# Altium schematic sheet Audio_Outputs.SchDoc
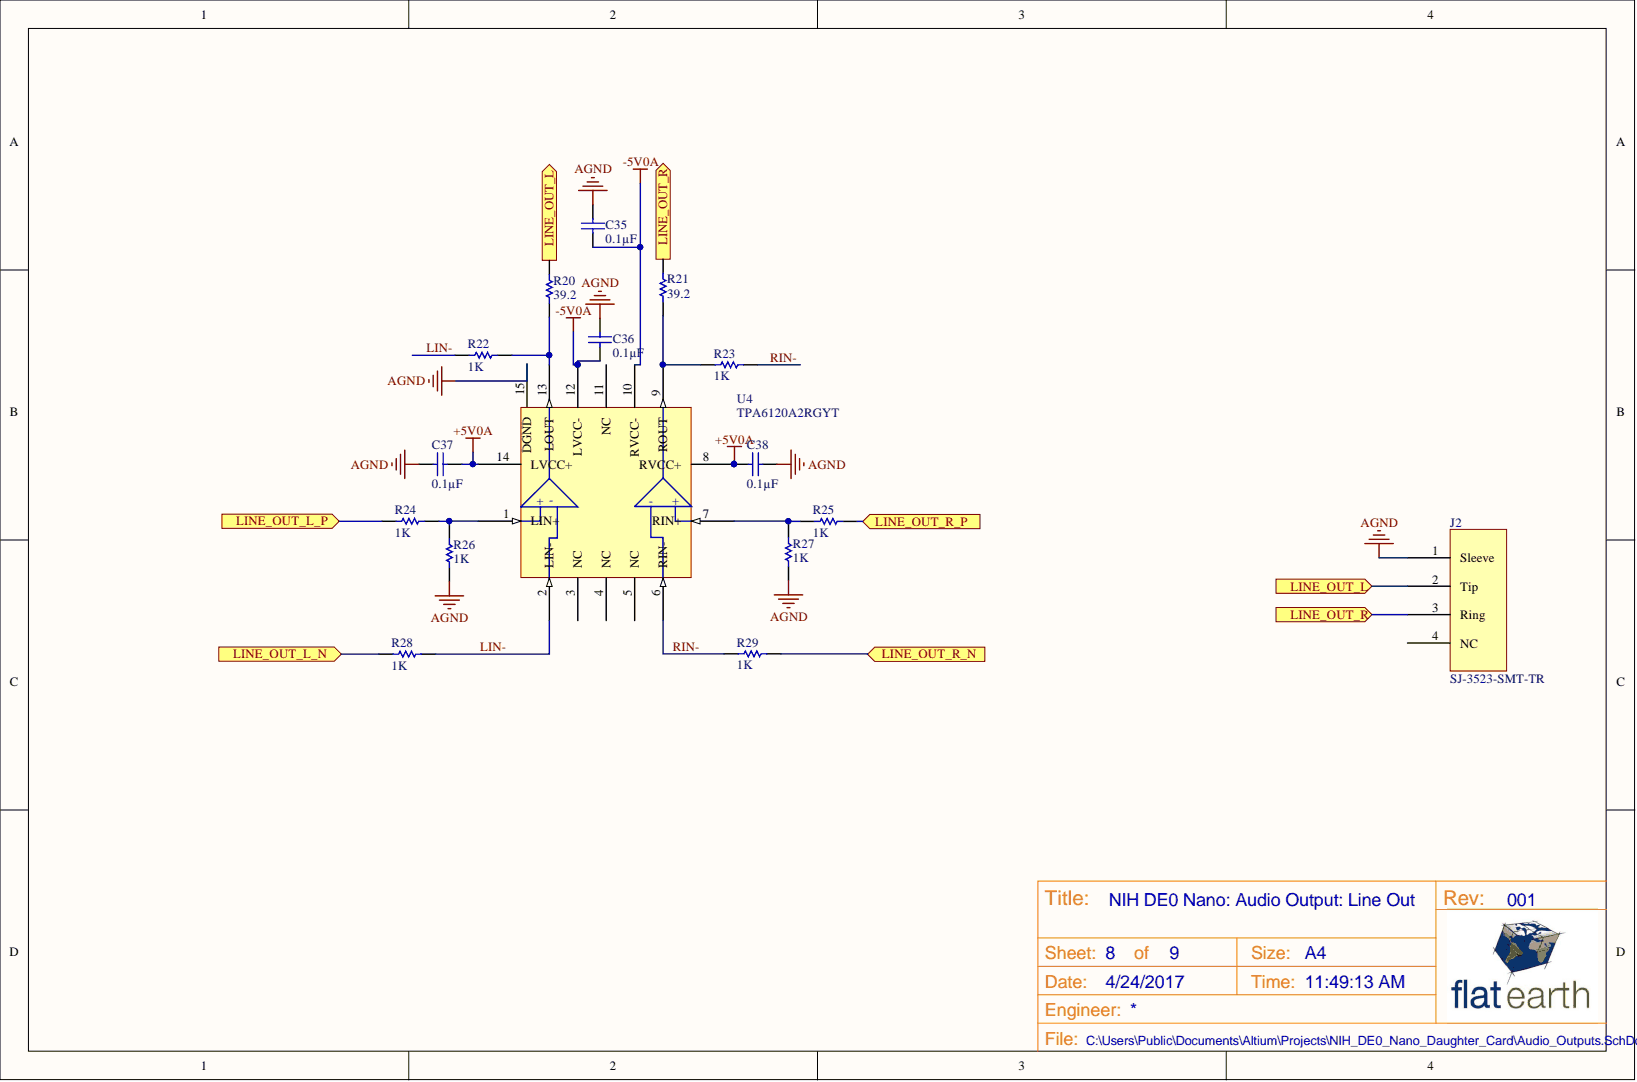
[diagram p0: Audio_Outputs.SchDoc]

## Parts
C35  Capacitance: 0.1µF  [3-0603_L]  (hidden comment: C1608X7R1H104K)
C36  Capacitance: 0.1µF  [3-0603_L]  (hidden comment: C1608X7R1H104K)
C37  Capacitance: 0.1µF  [3-0603_L]  (hidden comment: C1608X7R1H104K)
C38  Capacitance: 0.1µF  [3-0603_L]  (hidden comment: C1608X7R1H104K)
J2  SJ-3523-SMT-TR  [SJ-3523-SMT-TR]
R20  Resistance (Ohms): 39.2  [3-0603_R_L]  (hidden comment: ERJ-3EKF39R2V)
R21  Resistance (Ohms): 39.2  [3-0603_R_L]  (hidden comment: ERJ-3EKF39R2V)
R22  Resistance (Ohms): 1K  [3-0603_R_L]  (hidden comment: ERJ-3EKF1001V)
R23  Resistance (Ohms): 1K  [3-0603_R_L]  (hidden comment: ERJ-3EKF1001V)
R24  Resistance (Ohms): 1K  [3-0603_R_L]  (hidden comment: ERJ-3EKF1001V)
R25  Resistance (Ohms): 1K  [3-0603_R_L]  (hidden comment: ERJ-3EKF1001V)
R26  Resistance (Ohms): 1K  [3-0603_R_L]  (hidden comment: ERJ-3EKF1001V)
R27  Resistance (Ohms): 1K  [3-0603_R_L]  (hidden comment: ERJ-3EKF1001V)
R28  Resistance (Ohms): 1K  [3-0603_R_L]  (hidden comment: ERJ-3EKF1001V)
R29  Resistance (Ohms): 1K  [3-0603_R_L]  (hidden comment: ERJ-3EKF1001V)
U4  TPA6120A2RGYT  [PQFN50P350X350X100_HS-15N]

## Ports
LINE_OUT_L, LINE_OUT_L_N, LINE_OUT_L_P, LINE_OUT_R, LINE_OUT_R_N, LINE_OUT_R_P

## Nets
+5V0A: C37.2 C38.2 U4.8(RVCC+) U4.14(LVCC+)
-5V0A: C35.2 C36.2 U4.10(RVCC-) U4.12(LVCC-)
AGND: C35.1 C36.1 C37.1 C38.1 J2.1(Sleeve) R26.1 R27.1 U4.15(DGND)
LIN-: R22.1 R28.2 U4.2(LIN-)
LINE_OUT_L: J2.2(Tip) R20.1 ; port LINE_OUT_L
LINE_OUT_L_N: R28.1 ; port LINE_OUT_L_N
LINE_OUT_L_P: R24.1 ; port LINE_OUT_L_P
LINE_OUT_R: J2.3(Ring) R21.1 ; port LINE_OUT_R
LINE_OUT_R_N: R29.2 ; port LINE_OUT_R_N
LINE_OUT_R_P: R25.2 ; port LINE_OUT_R_P
RIN-: R23.1 R29.1 U4.6(RIN-)
NetR20_2: R20.2 R22.2 U4.13(LOUT)
NetR21_2: R21.2 R23.2 U4.9(ROUT)
NetR24_2: R24.2 R26.2 U4.1(LIN+)
NetR25_1: R25.1 R27.2 U4.7(RIN+)

Not connected: J2 4(NC); U4 3(NC) 4(NC) 5(NC) 11(NC)

## Library data of the parts
C35-C38 = C1608X7R1H104K: CAP CER 0.1UF 50V 10% X7R 0603; Manufacturer: TDK Corporation; Applications: General Purpose; Category: Capacitors; Component Kind: Standard; ComponentLink1Description: http://www.tdk.com/pdf/general_B11.pdf; ComponentLink1URL: http://www.tdk.com/pdf/general_B11.pdf; Features: -; Height - Seated (Max): -; Lead Spacing: -; Lead Style: -; Library Name: Schematic_Components.SchLib; Manufacturer Part Number: C1608X7R1H104K; Mounting Type: Surface Mount, MLCC; Operating Temperature: -55°C ~ 125°C; Package / Case: 0603 (1608 Metric); Packaging: Cut Tape (CT); Pricing 1: 10=0.048, 100=0.022, 250=0.018, 500=0.0154, 1000=0.012 (USD); Ratings: -; RoHS: RoHS Compliant; Size / Dimension: 0.063" L x 0.031" W (1.60mm x 0.80mm); Stock 1: 2442296; Supplier 1: Digi-Key; Supplier Part Number 1: 445-1314-1-ND; Temperature Coefficient: X7R; Thickness (Max): 0.037" (0.95mm); Tolerance: ±10%; Voltage - Rated: 50V
J2 = SJ-3523-SMT-TR: 3.50mm (0.141", 1/8", Mini Plug) - Headphone Phone Jack Stereo Connector Solder; Manufacturer: CUI Inc.; Actual Diameter: 0.142" (3.60mm); Body Color: Black; Current Rating: 1A; Gender: Female; Includes: 1 pc - 1 Connector; Internal Switch(s): Does Not Contain Switch; Mating Length/Depth: 0.551" (14.00mm); Number of Positions/Contacts: 3 Conductors, 3 Contacts; Shielding: Unshielded; Voltage - Rated: 12VDC; Body Material: -; Category: Connectors, Interconnects; Component Kind: Standard; ComponentLink1Description: http://www.cui.com/product/resource/digikeypdf/sj-352x-smt_series.pdf; ComponentLink1URL: http://www.cui.com/product/resource/digikeypdf/sj-352x-smt_series.pdf; Connector Type: Phone Jack; Contact Material - Plating: Silver; Features: Board Guide; Industry Recognized Mating Diameter: 3.50mm (0.141", 1/8", Mini Plug) - Headphone; Insulation Color: -; LatestRevisionDate: 17-Jul-2002; LatestRevisionNote: Re-released for DXP Platform.; Manufacturer Part Number: SJ-3523-SMT-TR; Mounting Type: Surface Mount, Right Angle; Packaging: Cut Tape (CT); Part Status: Active; Pricing 1: 1=1.34, 10=1.043, 100=0.7961, 500=0.63558 (USD); RoHS: RoHS Compliant; Signal Lines: Stereo; Stock 1: 104981; Supplier 1: Digi-Key; Supplier Part Number 1: CP-3523SJCT-ND; Termination: Solder; Library Name: NIH_DE0_Nano_Daughter_Card_Library.SchLib; Publisher: Altium Limited
R20 R21 = ERJ-3EKF39R2V: n RES SMD 39.2 OHM 1% 1/10W 0603; Manufacturer: Panasonic Electronic Components; Power (Watts): 0.1W, 1/10W; Temperature Coefficient: ±100ppm/°C; Category: Resistors; Component Kind: Standard; ComponentLink1Description: http://industrial.panasonic.com/www-cgi/jvcr13pz.cgi?E+PZ+3+AOA0002+ERJ3EKF39R2V+7+WW; ComponentLink1URL: http://industrial.panasonic.com/www-cgi/jvcr13pz.cgi?E+PZ+3+AOA0002+ERJ3EKF39R2V+7+WW; Composition: Thick Film; Features: Automotive AEC-Q200; Height: 0.022" (0.55mm); Library Name: Schematic_Components.SchLib; Manufacturer Part Number: ERJ-3EKF39R2V; Number of Terminations: 2; Operating Temperature: -55°C ~ 155°C; Package / Case: 0603 (1608 Metric); Packaging: Cut Tape (CT); Part Status: Active; Pricing 1: 1=0.1, 50=0.0114, 100=0.0084, 250=0.00644, 500=0.00514, 1000=0.00379 (USD); RoHS: RoHS Compliant; Size / Dimension: 0.063" L x 0.031" W (1.60mm x 0.80mm); Stock 1: 34148; Supplier 1: Digi-Key; Supplier Device Package: 0603; Supplier Part Number 1: P39.2HCT-ND; Tolerance: ±1%
R22-R29 = ERJ-3EKF1001V: RES 1.00K OHM 1/10W 1% 0603 SMD; Manufacturer: Panasonic - ECG; Power (Watts): 0.1W, 1/10W; Temperature Coefficient: ±100ppm/°C; Category: Resistors; Component Kind: Standard; ComponentLink1Description: http://industrial.panasonic.com/www-cgi/jvcr13pz.cgi?E+PZ+3+AOA0002+ERJ3EKF1001V+7+WW; ComponentLink1URL: http://industrial.panasonic.com/www-cgi/jvcr13pz.cgi?E+PZ+3+AOA0002+ERJ3EKF1001V+7+WW; Composition: Thick Film; Features: -; Height: 0.022" (0.55mm); Library Name: Schematic_Components.SchLib; Manufacturer Part Number: ERJ-3EKF1001V; Number of Terminations: 2; Package / Case: 0603 (1608 Metric); Packaging: Cut Tape (CT); Pricing 1: 1=0.04, 50=0.0138, 100=0.0105, 250=0.0078, 500=0.00576, 1000=0.00384 (USD); RoHS: RoHS Compliant; Size / Dimension: 0.063" L x 0.031" W (1.60mm x 0.80mm); Stock 1: 5088743; Supplier 1: Digi-Key; Supplier Part Number 1: P1.00KHCT-ND; Tolerance: ±1%
U4 = TPA6120A2RGYT: Amplifier IC Headphones, 2-Channel (Stereo) Class AB 14-VQFN (3.5x3.5); Manufacturer: Texas Instruments; Max Output Power x Channels @ Load: 80mW x 2 @ 600 Ohm; Voltage - Supply: 10 V ~ 30 V, ±5 V ~ 15 V; Category: Integrated Circuits (ICs); Component Kind: Standard; ComponentLink1Description: http://www.ti.com/lit/ds/symlink/tpa6120a2.pdf; ComponentLink1URL: http://www.ti.com/lit/ds/symlink/tpa6120a2.pdf; Features: Differential Inputs, Short-Circuit and Thermal Protection; LatestRevisionDate: 17-Jul-2002; LatestRevisionNote: Re-released for DXP Platform.; Manufacturer Part Number: TPA6120A2RGYT; Mounting Type: Surface Mount; Operating Temperature: -40°C ~ 85°C (TA); Output Type: Headphones, 2-Channel (Stereo); Package / Case: 14-VFQFN Exposed Pad; Packaging: Cut Tape (CT); Part Status: Active; Pricing 1: 1=6.04, 10=5.424, 100=4.4501 (USD); RoHS: RoHS Compliant; Stock 1: 867; Supplier 1: Digi-Key; Supplier Device Package: 14-VQFN (3.5x3.5); Supplier Part Number 1: 296-38029-1-ND; Type: Class AB; Library Name: NIH_DE0_Nano_Daughter_Card_Library.SchLib; Publisher: Altium Limited
